# Altium schematic sheet ADC.SchDoc(ADC)
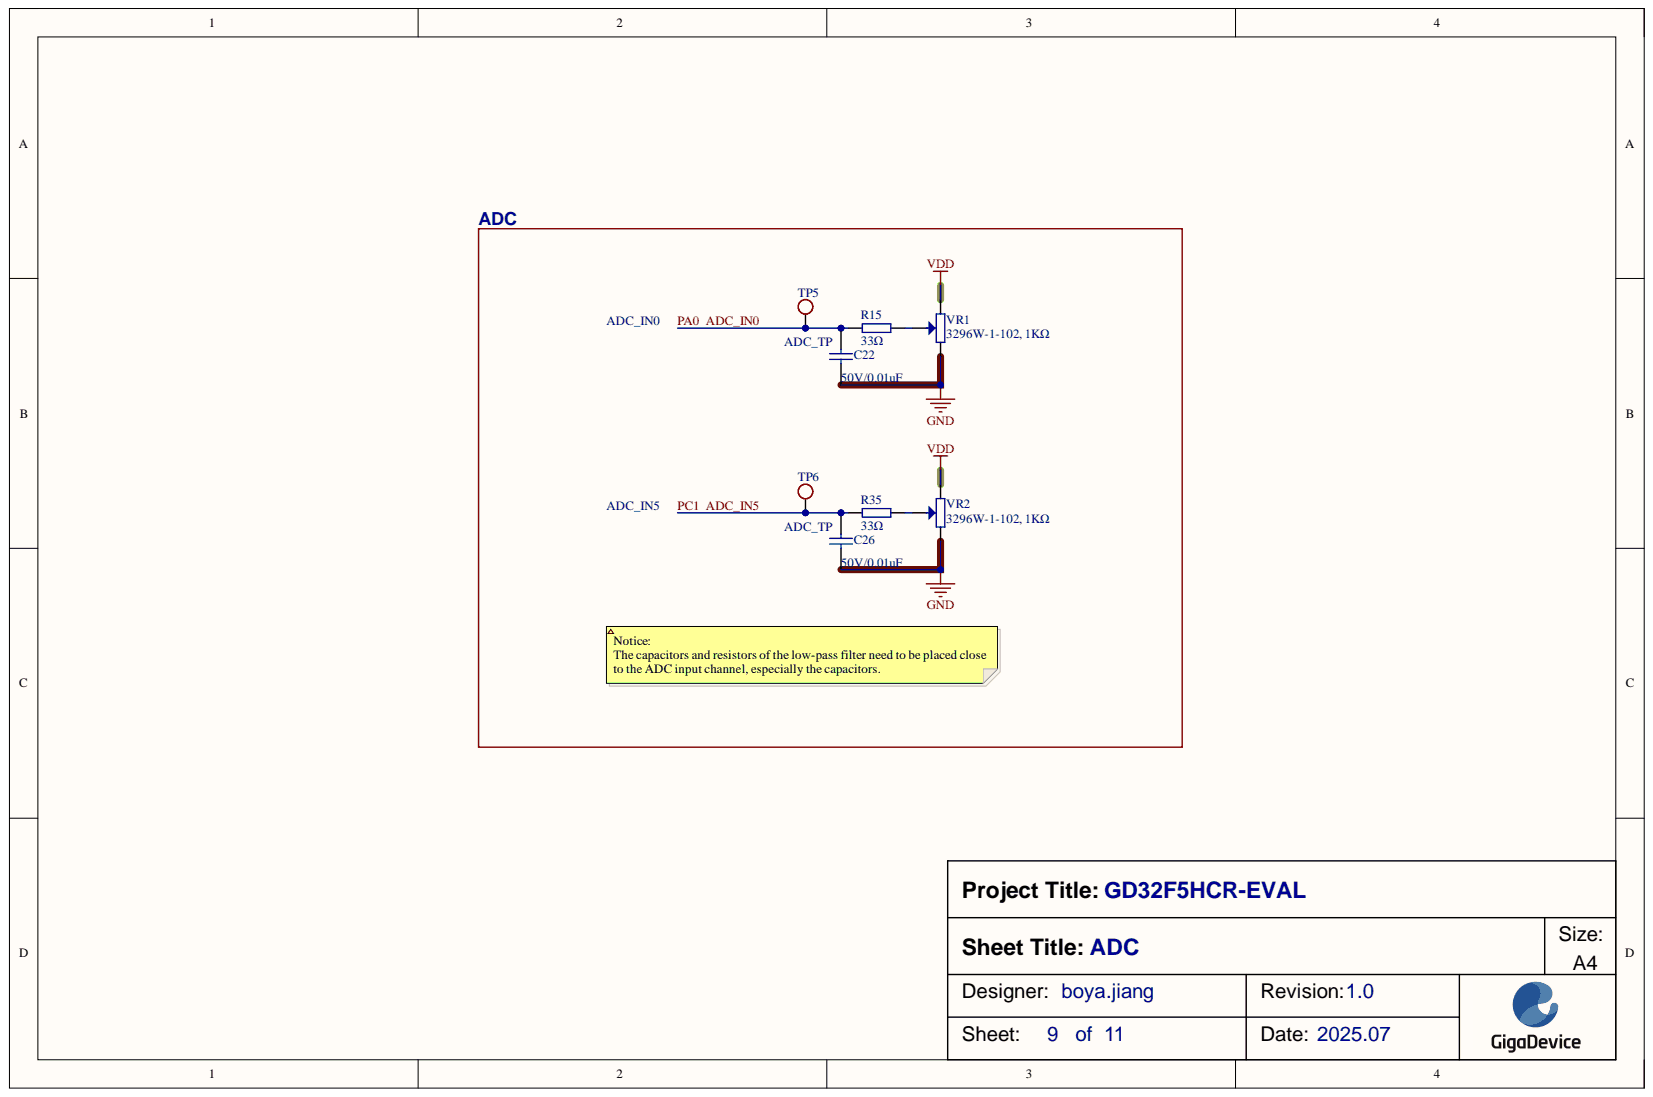
[diagram p0: ADC.SchDoc]

## Parts
C22  50V/0.01uF  [0603-C]
C26  50V/0.01uF  [0603-C]
R15  33Ω  [0603-R]
R35  33Ω  [0603-R]
TP5  5003  [SIP-1, TP]  (value not drawn)  (no BOM)
TP6  5003  [SIP-1, TP]  (value not drawn)  (no BOM)
VR1  3296W-1-102, 1KΩ  [3296W]
VR2  3296W-1-102, 1KΩ  [3296W]

## Nets
GND: C22.1 C26.1 VR1.1 VR2.1
VDD: VR1.3 VR2.3
ADC_IN0: C22.2 R15.1 TP5.1
ADC_IN5: C26.2 R35.1 TP6.1
NetR15_2: R15.2 VR1.2
NetR35_2: R35.2 VR2.2

## Library data of the parts
C22 C26 = 50V/0.1uF: 表贴电容; Component Kind: Standard; Library Name: KLTC-电容.SchLib
R15 R35 = 470Ω: 表贴电阻; Component Kind: Standard; Library Name: KLTC-电阻.SchLib
TP5 TP6 = HEADER 1: 弹簧探针连接器, 测试点; TP FOR: ADC_TP; Library Name: boyajiang.SchLib
VR1 VR2 = POT2: 直插可调电位器; Component Kind: Standard; Library Name: Schlib1.SchLib
